# Altium schematic sheet 04_SRAD_Ejection_Charges.SchDoc.SchDoc(04_SRAD_Ejection_Charges.SchDoc)
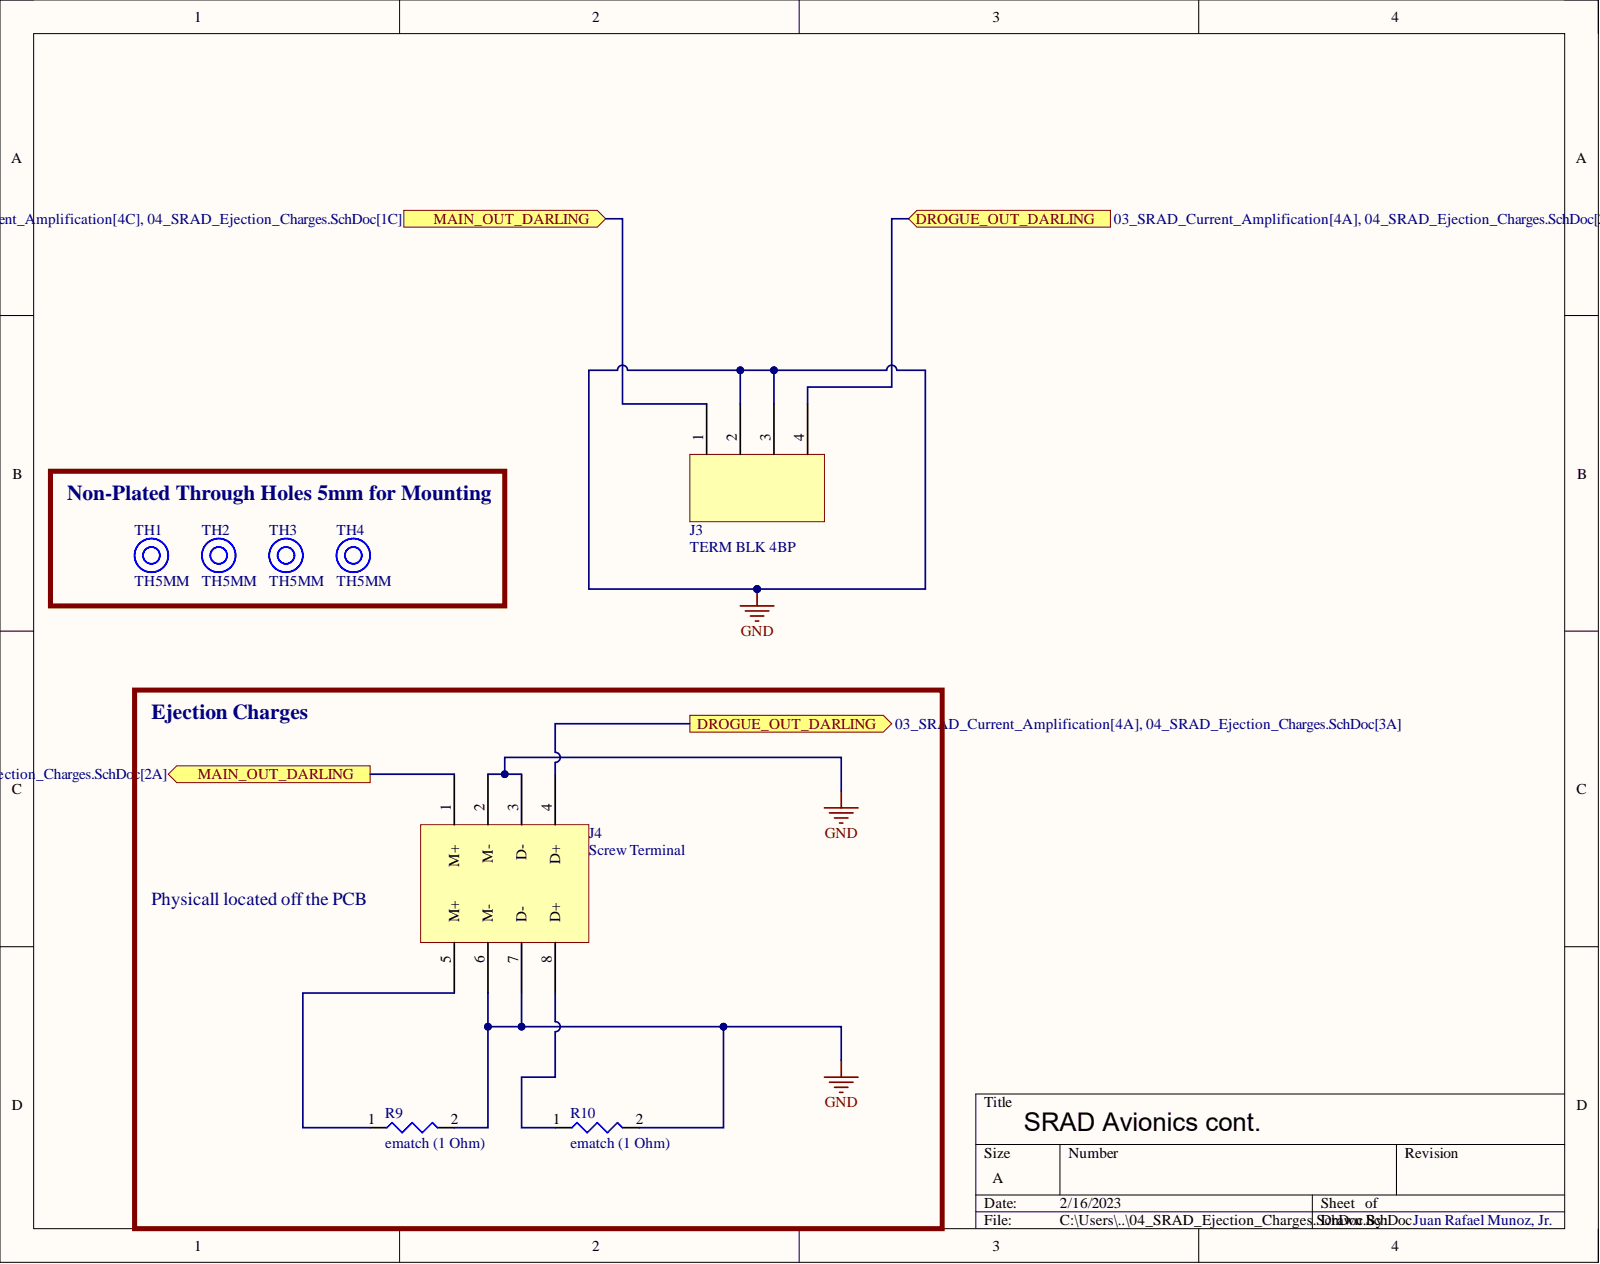
[diagram p0: 04_SRAD_Ejection_Charges.SchDoc.SchDoc]

## Parts
J3  TERM BLK 4BP  [PCB TERM BLK 4P 2.54MM]
J4  Screw Terminal  [Barrier Screw Terminal]
R9  ematch (1 Ohm)  [ematch]
R10  ematch (1 Ohm)  [ematch]
TH1  TH5MM  [Mounting Hole Non-Plated]
TH2  TH5MM  [Mounting Hole Non-Plated]
TH3  TH5MM  [Mounting Hole Non-Plated]
TH4  TH5MM  [Mounting Hole Non-Plated]

## Ports
DROGUE_OUT_DARLING, MAIN_OUT_DARLING

## Nets
GND: J3.2 J3.3 J4.2 J4.3 J4.6 J4.7 R9.2 R10.2
DROGUE_OUT_DARLING: J3.4 J4.4 ; port DROGUE_OUT_DARLING
MAIN_OUT_DARLING: J3.1 J4.1 ; port MAIN_OUT_DARLING
NetJ4_5: J4.5 R9.1
NetJ4_8: J4.8 R10.1

## Library data of the parts
J3 = PCB TERM BLK 4P 2.54MM: 4 Position Wire to Board Terminal Block Horizontal with Board 0.100" (2.54mm) Through Hole 10A 150V 16-30 AWG 0.2 Nm M1.6 screw -40°C ~ 105°C; Manufacturer: TE Connectivity; Manufacturer Part Number: 282834-4; Approvals: UL; Closed End: No (Without); Connector Type: Receptacle; Number of Circuits: 4; Number of Levels: 1; Plating: Brass,Steel; Screwless Terminal Block: Yes (With); Tail Length: 3.4mm; Wire Gauge (Max): 30AWG; Category: Terminal Blocks; Color: Green; Component Kind: Standard; ComponentLink1Description: https://datasheet.ciiva.com/5741/1605505-5741440.pdf?src-supplier=Farnell; ComponentLink1URL: https://datasheet.ciiva.com/5741/1605505-5741440.pdf?src-supplier=Farnell; ComponentLink2Description: https://datasheet.ciiva.com/30254/eng-cs-1308389-eurostyle-terminal-blocks-0607-30254374.pdf?src-supplier=Onlinecomponents.com; ComponentLink2URL: https://datasheet.ciiva.com/30254/eng-cs-1308389-eurostyle-terminal-blocks-0607-30254374.pdf?src-supplier=Onlinecomponents.com; ComponentLink3Description: https://datasheet.ciiva.com/14609/70087596-14609846.pdf?src-supplier=Allied+Electronics; ComponentLink3URL: https://datasheet.ciiva.com/14609/70087596-14609846.pdf?src-supplier=Allied+Electronics; ComponentLink4Description: https://datasheet.ciiva.com/3141/eng-cs-1308389-eurostyle-terminal-blocks-0607-3141117.pdf?src-supplier=Avnet; ComponentLink4URL: https://datasheet.ciiva.com/3141/eng-cs-1308389-eurostyle-terminal-blocks-0607-3141117.pdf?src-supplier=Avnet; ComponentLink5Description: https://datasheet.ciiva.com/823/eng-cd-282834-c1-823474.pdf?src-supplier=Digi-Key; ComponentLink5URL: https://datasheet.ciiva.com/823/eng-cd-282834-c1-823474.pdf?src-supplier=Digi-Key; Contact Material: Brass; Contact Plating: Tin; Current: 10A; Current Rating: 10A; Depth: 6.5mm; ELV: Compliant; Flammability Rating: UL94 V-0; Housing Color: Green; Housing Material: Polyamide; Interlock: No (Without); Lead Free: Lead Free; Length: 10.62mm; Max Current Rating: 10A; Max Operating Temperature: 105°C; Max Supply Voltage (AC): 150V; Max Voltage Rating (AC): 150V; Min Operating Temperature: -40°C; Mounting Technology: Through Hole; Number of Contacts: 4; Number of Poles: 4; Number of Positions: 4; Number of Positions Per Level: 4; Number of Rows: 1; Orientation: Straight; Package Quantity: 150; Packaging: Bulk; Pitch: 2.54mm; Pricing 1: 1=3.48000, 10=2.64900, 25=2.48120, 50=2.20540, 100=2.15740, 250=1.98964, 500=1.79786, 1000=1.59409, 5000=1.49821 (USD); Radiation Hardening: No; RoHS: Yes; RoHS Compliant: Yes; Screw Flange: No (Without); Series: Buchanan; Side Stackable: Yes (With); Stock 1: 8416; Supplier 1: Digi-Key; Supplier Part Number 1: A98335-ND; Termination: Solder; Thread Size: M1.6; Voltage: 150V; Voltage Rating: 150V; Wire Gauge (Min): 30AWG; Wire/Cable Gauge: 30AWG; Library Name: SRAD_SAC_Schlib.SchLib
J4 = Barrier Screw Terminal: Component Kind: Standard; Library Name: SRAD_SAC_Schlib.SchLib
R9 R10 = ematch: ematch of 1.0-1.5 Ohms of resistance that lights black powder ejection charges.; Component Kind: Standard; Library Name: SRAD_SAC_Schlib.SchLib
TH1-TH4 = Mounting Hole Non-Plated: Non-plated THT 5mm diameter; Component Kind: Standard; Library Name: SRAD_SAC_Schlib.SchLib
